# Altium schematic sheet Oscillator.SchDoc(Oscillator)
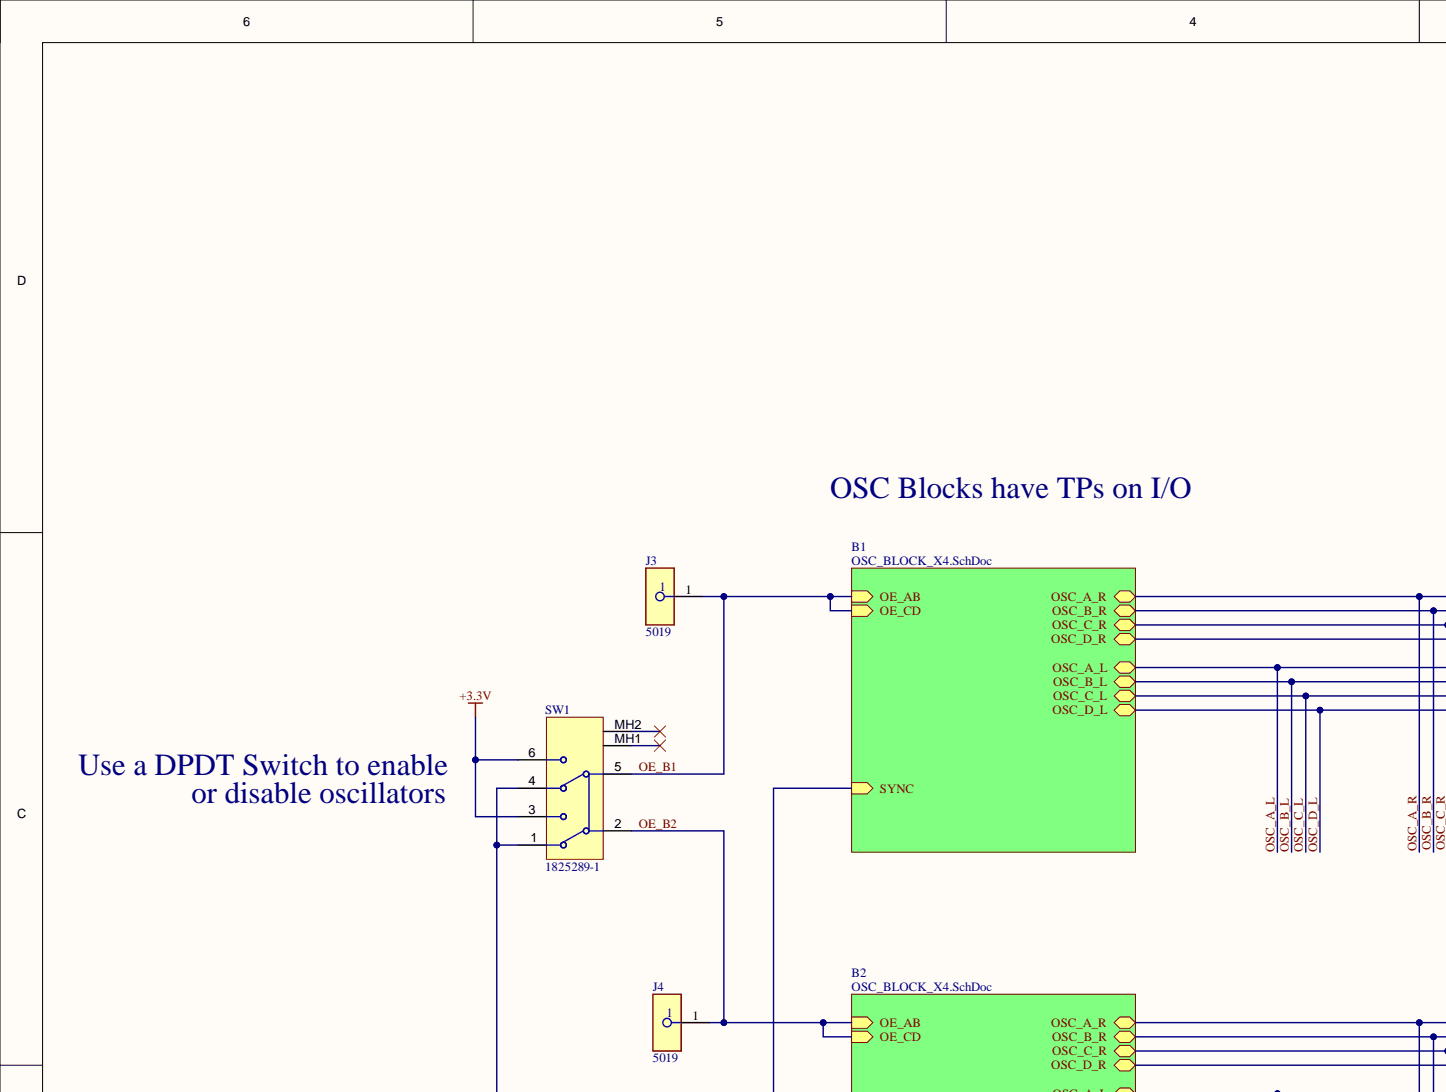
[diagram p0: Oscillator.SchDoc, top left]
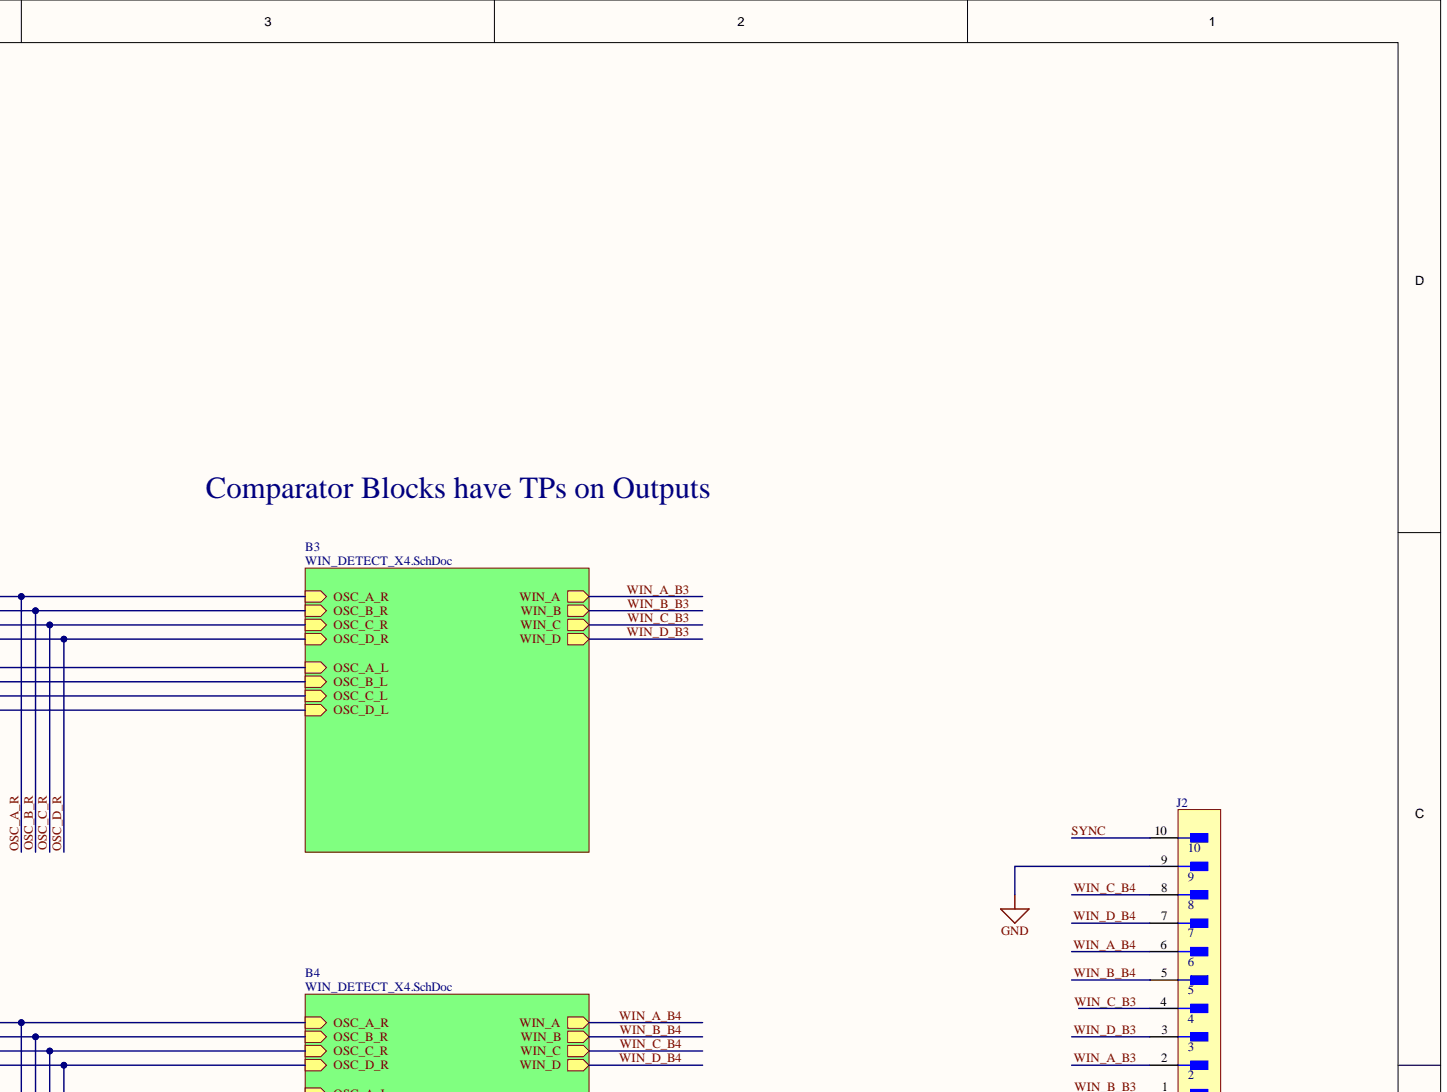
[diagram p1: Oscillator.SchDoc, top right]
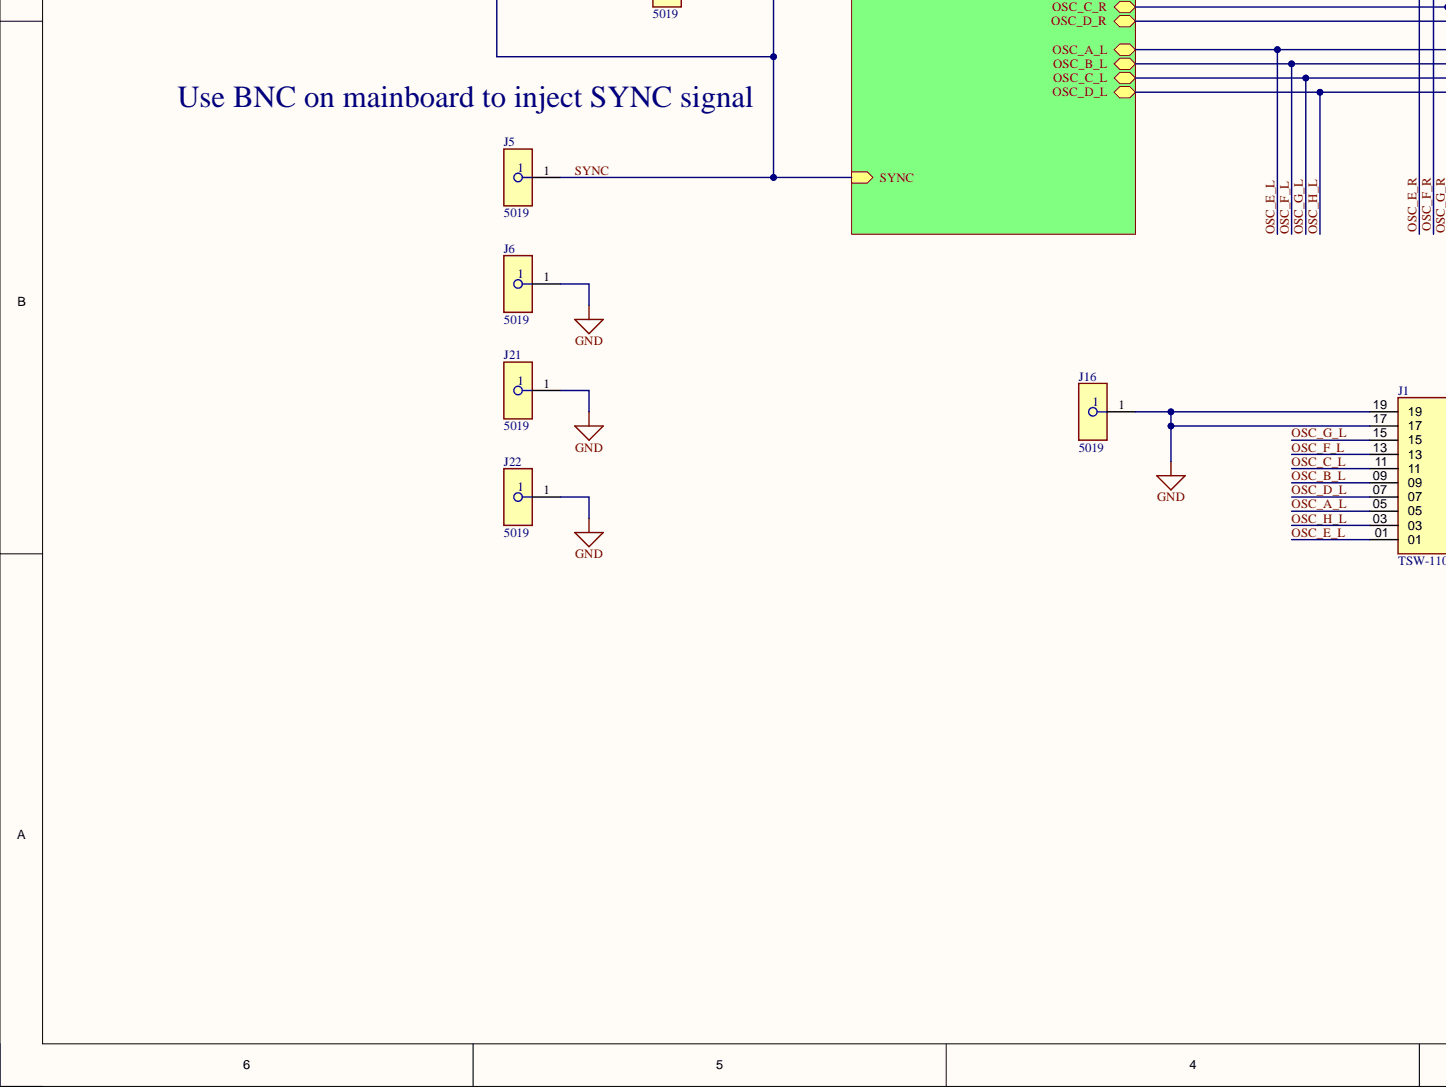
[diagram p2: Oscillator.SchDoc, bottom left]
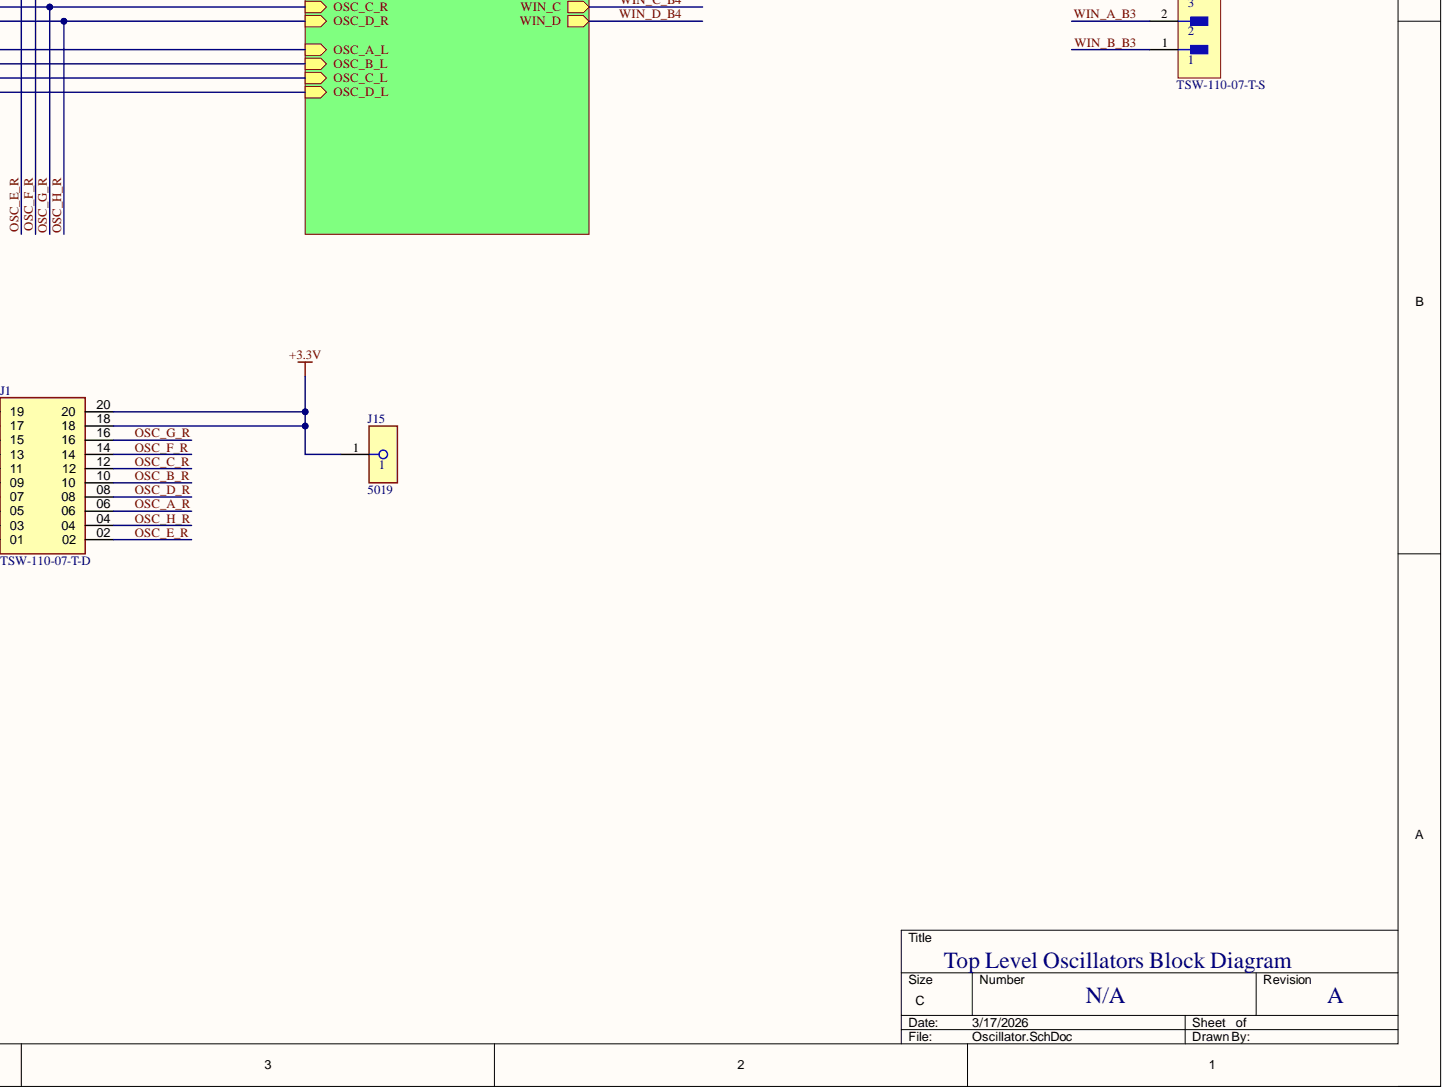
[diagram p3: Oscillator.SchDoc, bottom right]

## Parts
J1  TSW-110-07-T-D
J2  TSW-110-07-T-S
J3  5019
J4  5019
J5  5019
J6  5019
J15  5019
J16  5019
J21  5019
J22  5019
SW1  1825289-1

## Nets
+3.3V: J1.18 J1.20 J15.1 SW1.3 SW1.6
GND: J1.17 J1.19 J2.9 J6.1 J16.1 J21.1 J22.1
OE_B1: J3.1 SW1.5
OE_B2: J4.1 SW1.2
OSC_A_L: J1.05
OSC_A_R: J1.06
OSC_B_L: J1.09
OSC_B_R: J1.10
OSC_C_L: J1.11
OSC_C_R: J1.12
OSC_D_L: J1.07
OSC_D_R: J1.08
OSC_E_L: J1.01
OSC_E_R: J1.02
OSC_F_L: J1.13
OSC_F_R: J1.14
OSC_G_L: J1.15
OSC_G_R: J1.16
OSC_H_L: J1.03
OSC_H_R: J1.04
SYNC: J2.10 J5.1 SW1.1 SW1.4
WIN_A_B3: J2.2
WIN_A_B4: J2.6
WIN_B_B3: J2.1
WIN_B_B4: J2.5
WIN_C_B3: J2.4
WIN_C_B4: J2.8
WIN_D_B3: J2.3
WIN_D_B4: J2.7
NetSW1_MH1: SW1.MH1
NetSW1_MH2: SW1.MH2

## Library data of the parts
J1 = CMP-002-00074-4: Board Connector, 20 Contact(s), 2 Row(s), Male, Straight, 0.1 inch Pitch, Solder Terminal, Black Insulator, Receptacle; Component Kind: Standard; Connector Type: BOARD CONNECTOR; Mounting Technology: Board; RoHS Compliant: Yes; Library Name: Ben Anderson
J2 = CMP-002-00073-1: Board Connector, 10 Contact(s), 1 Row(s), Male, Straight, 0.1 inch Pitch, Solder Terminal, Black Insulator; Component Kind: Standard; Connector Type: BOARD CONNECTOR; Mounting Technology: Board; RoHS Compliant: Yes; Library Name: Ben Anderson
J3-J6 J15 J16 J21 J22 = CMP-00030-00001-2: Interconnection Device; Component Kind: Standard; RoHS Compliant: Yes; Library Name: Ben Anderson
SW1 = CMP-011-00003-2: Slide Switch, DPDT, 0.3A, 125 V, -20 to 85 degC, 6-Pin THD, RoHS, Bulk; Manufacturer: TE Connectivity; Current Rating: 300mA; Max Contact Resistance: 30mohm; Max Voltage Rating (AC): 125V; Operating Force: 600gf; Case/Package: 1825289-1; Component Kind: Standard; Contact Finish: Silver; Contact Material: Copper; Contact Plating: Silver; Datasheet URL: http://www.te.com/commerce/DocumentDelivery/DDEController?Action=showdoc&DocId=Catalog+Section%7F1308111-1_SWITCHES_CORE_PROGRAM_CATALOG%7F0308%7Fpdf%7FEnglish%7FENG_CS_1308111-1_SWITCHES_CORE_PROGRAM_CATALOG_0308.pdf%7F1825289-1; Datasheet Version: Mar-08; Manufacturer URL: http://www.te.com/; Max Current Rating: 300mA; Max Operating Temperature: 85°C; Min Operating Temperature: -20°C; Mounting Technology: TH; Package Description: 6-Pin Through Hole Device, Body 16 x 6.7 mm, Height 12.05 mm; Package Quantity: 560; Package Reference: 1825289-1; Package URL: http://www.te.com/commerce/DocumentDelivery/DDEController?Action=showdoc&DocId=Customer+Drawing%7F1825289%7FB3%7Fpdf%7FEnglish%7FENG_CD_1825289_B3.pdf%7F1825289-1; Packaging: Bulk; RoHS Compliant: True; Throw Configuration: DPDT; Voltage Rating (AC): 125V; Library Name: Ben Anderson
